# Altium schematic sheet Aux_SC.SchDoc(Aux_SC)
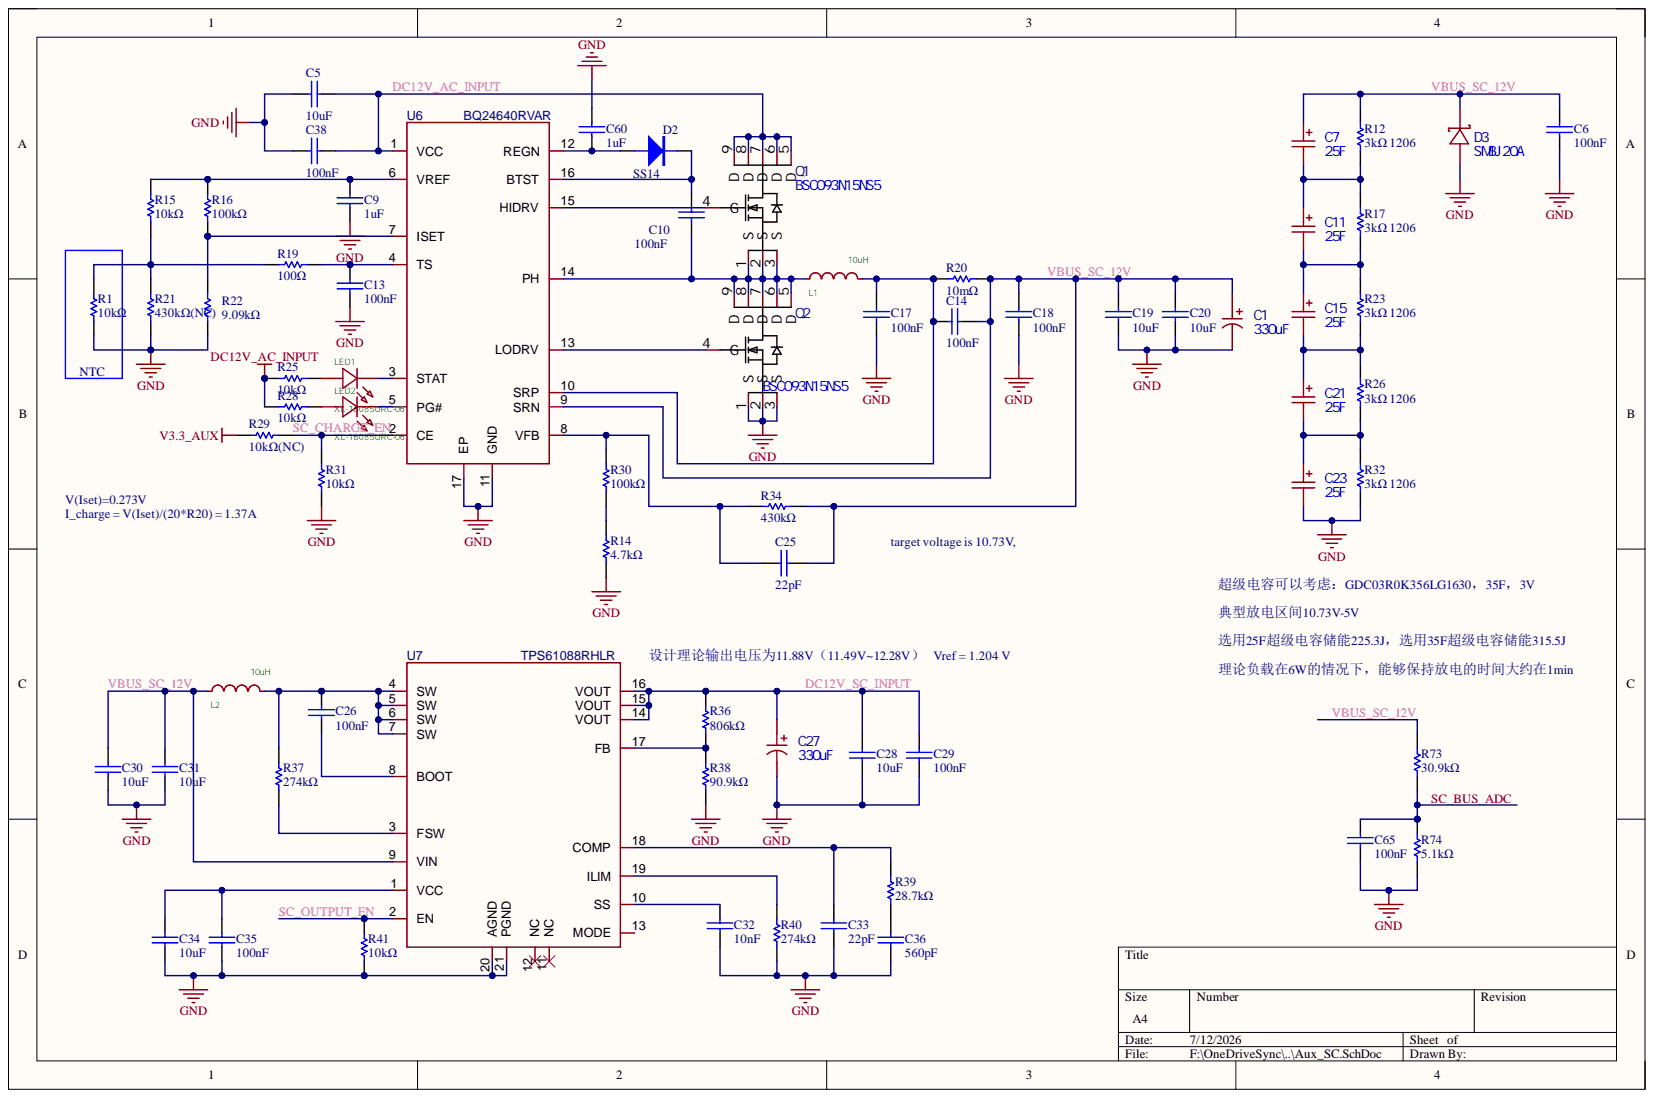
[diagram p0: Aux_SC.SchDoc]

## Parts
C1  330uF  [CAP-SMD_BD8.0-L8.3-W8.3-FD]
C5  10uF  [0805C]
C6  100nF  [0805C]
C7  25F  [CAP-TH_BD16.0-P7.50-D1.2-FD]
C9  1uF  [0402C]
C10  100nF  [0603C]
C11  25F  [CAP-TH_BD16.0-P7.50-D1.2-FD]
C13  100nF  [0603C]
C14  100nF  [0402C]
C15  25F  [CAP-TH_BD16.0-P7.50-D1.2-FD]
C17  100nF  [0603C]
C18  100nF  [0603C]
C19  10uF  [0805C]
C20  10uF  [0805C]
C21  25F  [CAP-TH_BD16.0-P7.50-D1.2-FD]
C23  25F  [CAP-TH_BD16.0-P7.50-D1.2-FD]
C25  22pF  [0402C]
C26  100nF  [0603C]
C27  330uF  [CAP-SMD_BD8.0-L8.3-W8.3-FD]
C28  10uF  [0805C]
C29  100nF  [0603C]
C30  10uF  [0805C]
C31  10uF  [0805C]
C32  10nF  [0402C]
C33  22pF  [0402C]
C34  10uF  [0805C]
C35  100nF  [0603C]
C36  560pF  [0402C]
C38  100nF  [0603C]
C60  1uF  [0402C]
C65  100nF  [0402C]
D2  SS14  [SOD-123]
D3  SMBJ20A  [SMB_L4.6-W3.6-LS5.3-RD]
L1  10uH  [IND-SMD_L5.7-W5.2_SMPI0520]
L2  10uH  [IND-SMD_L5.7-W5.2_SMPI0520]
LED1  XL-1608SURC-06  [LED0603-RD_RED]
LED2  XL-1608SURC-06  [LED0603-RD_RED]
Q1  BSC093N15NS5  [PG-TDSON-8_L5.0-W6.0-P1.27-LS6.2-BL-EP]
Q2  BSC093N15NS5  [PG-TDSON-8_L5.0-W6.0-P1.27-LS6.2-BL-EP]
R1  10kΩ  [0402R]
R12  3kΩ 1206  [1206R]
R14  4.7kΩ  [0402R]
R15  10kΩ  [0402R]
R16  100kΩ  [0402R]
R17  3kΩ 1206  [1206R]
R19  100Ω  [0402R]
R20  10mΩ  [1206R]
R21  430kΩ(NC)  [0402R]
R22  9.09kΩ  [0402R]
R23  3kΩ 1206  [1206R]
R25  10kΩ  [0402R]
R26  3kΩ 1206  [1206R]
R28  10kΩ  [0402R]
R29  10kΩ(NC)  [0402R]
R30  100kΩ  [0402R]
R31  10kΩ  [0402R]
R32  3kΩ 1206  [1206R]
R34  430kΩ  [0402R]
R36  806kΩ  [0402R]
R37  274kΩ  [0402R]
R38  90.9kΩ  [0402R]
R39  28.7kΩ  [0402R]
R40  274kΩ  [0402R]
R41  10kΩ  [0402R]
R73  30.9kΩ  [0402R]
R74  5.1kΩ  [0402R]
U6  BQ24640RVAR  [VQFN-16_L3.6-W3.6-P0.50-BL-EP]
U7  TPS61088RHLR  [VQFN-20_L4.6-W3.6-P0.50-BL]

## Nets
GND: C1.2 C5.2 C6.1 C9.1 C13.1 C17.1 C18.1 C19.1 C20.1 C23.2 C27.2 C28.1 C29.1 C30.1 C31.1 C32.1 C33.1 C34.1 C35.1 C36.1 C38.2 C60.2 C65.1 D3.2 Q2.1(S) Q2.2(S) Q2.3(S) R1.1 R14.2 R21.1 R22.1 R31.2 R32.1 R38.1 R40.1 R41.1 R74.1 U6.11(GND) U6.17(EP) U7.20(AGND) U7.21(PGND)
V3.3_AUX: R29.2
DC12V_AC_INPUT: C5.1 C38.1 Q1.5(D) Q1.6(D) Q1.7(D) Q1.8(D) Q1.9(D) R25.2 R28.2 U6.1(VCC)
DC12V_SC_INPUT: C27.1 C28.2 C29.2 R36.2 U7.14(VOUT) U7.15(VOUT) U7.16(VOUT)
SC_BUS_ADC: C65.2 R73.1 R74.2
SC_CHARGE_EN: R29.1 R31.1 U6.2(CE)
SC_OUTPUT_EN: R41.2 U7.2(EN)
VBUS_SC_12V: C1.1 C6.2 C7.1 C14.2 C18.2 C19.2 C20.2 C25.1 C30.2 C31.2 D3.1 L2.1 R12.2 R20.2 R34.1 R73.2 U6.9(SRN) U7.9(VIN)
NetC7_2: C7.2 C11.1 R12.1 R17.2
NetC9_2: C9.2 R15.2 R16.2 U6.6(VREF)
NetC10_1: C10.1 L1.1 Q1.1(S) Q1.2(S) Q1.3(S) Q2.5(D) Q2.6(D) Q2.7(D) Q2.8(D) Q2.9(D) U6.14(PH)
NetC10_2: C10.2 D2.K U6.16(BTST)
NetC11_2: C11.2 C15.1 R17.1 R23.2
NetC13_2: C13.2 R19.1 U6.4(TS)
NetC14_1: C14.1 C17.2 L1.2 R20.1 U6.10(SRP)
NetC15_2: C15.2 C21.1 R23.1 R26.2
NetC21_2: C21.2 C23.1 R26.1 R32.2
NetC25_2: C25.2 R30.1 R34.2 U6.8(VFB)
NetC26_1: C26.1 U7.8(BOOT)
NetC26_2: C26.2 L2.2 R37.2 U7.4(SW) U7.5(SW) U7.6(SW) U7.7(SW)
NetC32_2: C32.2 U7.10(SS)
NetC33_2: C33.2 R39.2 U7.18(COMP)
NetC34_2: C34.2 C35.2 U7.1(VCC)
NetC36_2: C36.2 R39.1
NetC60_1: C60.1 D2.A U6.12(REGN)
NetLED1_1: LED1.1 U6.3(STAT)
NetLED1_2: LED1.2 R25.1
NetLED2_1: LED2.1 U6.5(PG#)
NetLED2_2: LED2.2 R28.1
NetQ1_4: Q1.4(G) U6.15(HIDRV)
NetQ2_4: Q2.4(G) U6.13(LODRV)
NetR1_2: R1.2 R15.1 R19.2 R21.2
NetR14_1: R14.1 R30.2
NetR16_1: R16.1 R22.2 U6.7(ISET)
NetR36_1: R36.1 R38.2 U7.17(FB)
NetR37_1: R37.1 U7.3(FSW)
NetR40_2: R40.2 U7.19(ILIM)

Not connected: U7 11(NC) 12(NC) 13(MODE)

## Library data of the parts
C1 C27 = RVT1E331M0810 330UF 25V: C970701; Manufacturer: DMBJ(振宝佳); Add into BOM: yes; Lifetime: 2800hrs@105℃; Ripple Current: 228mA@120Hz; Tolerance: ±20%; Voltage Rating: 25V; Component Kind: Standard; Convert to PCB: yes; Datasheet: https://item.szlcsc.com/datasheet/RVT1E331M0810%2520330UF%252025V/1060429.html; Device: RVT1E331M0810 330UF 25V; Diameter: 8mm; Equivalent Series Resistance(ESR): -; Height - Seated (Max): 10.2mm; JLCPCB Part Class: 扩展库; LCSC Part Name: 330uF ±20% 25V; Manufacturer Part: RVT1E331M0810 330UF 25V; Operating Temperature: -55℃~+105℃; PCB3D: CAP-SMD_BD8.0-L8.3-W8.3-FD; Supplier: LCSC; Supplier Footprint: SMD,D8xL10.2mm; Supplier Part: C970701; Symbol: RVT1E331M0810 330UF 25V; Value: 330uF
C5 C6 C9 C10 C13 C14 C17-C20 C25 C26 C28-C36 C38 C60 C65 = CAP: 无极性电容; Component Kind: Standard; Library Name: 电容.IntLib
C7 C11 C15 C21 C23 = ERF1VM222L25OT: BCT2R7M256YS1625A2NP01; Manufacturer: EDLCON(绿宝石); Manufacturer Part: BCC2R7S205YS0812A2NS01; Add into BOM: yes; Lifetime: 6000hrs@105℃; Pin Spacing: 7.5mm; Symbol: CAP; Tolerance: ±20%; Voltage Rating: 35V; Component Kind: Standard; Convert to PCB: yes; Datasheet: https://item.szlcsc.com/datasheet/ERF1VM222L25OT/107763.html; Device: ERF1VM222L25OT; Diameter: 16mm; Equivalent Series Resistance(ESR): -; Height - Seated (Max): 25mm; JLCPCB Part Class: Extended Part; LCSC Part Name: 2200uF ±20% 35V; PCB3D: CAP-TH_D16.0-H25.0-P7.50; Ripple Current: -; Supplier: LCSC; Supplier Footprint: 插件,D16xL25mm; Supplier Part: C39832982; Value: 25F
D2 = DIODE: 普通二极管; Component Kind: Standard; Library Name: 二极管.IntLib
D3 = SMBJ20A_C151257: Manufacturer: Littelfuse(美国力特); Add into BOM: yes; Clamping Voltage: 32.4V; Peak Pulse Current (Ipp): 18.6A; Peak Pulse Power Dissipation (Ppp): 600W; Reverse Stand-Off Voltage (Vrwm): 20V; Type: TVS; Voltage - Breakdown: 22.2V; Component Kind: Standard; Convert to PCB: yes; Datasheet: https://item.szlcsc.com/datasheet/SMBJ20A/162597.html; Device: SMBJ20A_C151257; JLCPCB Part Class: 扩展库; LCSC Part Name: SMBJ20A; Manufacturer Part: SMBJ20A; PCB3D: SMB_L4.6-W3.6-LS5.3-RD; Supplier: LCSC; Supplier Footprint: SMB(DO-214AA); Supplier Part: C151257; Symbol: SMBJ20A_C151257
L1 L2 = FXL0630-3R3-M: L; Manufacturer: cjiang(长江微电); Add into BOM: yes; DC Resistance (DCR): 22mΩ; Rated Current: 8.5A; Saturation Current (Isat): 9.5A; Tolerance: ±20%; Type: 一体成型电感; Value: 3.3uH; Component Kind: Standard; Convert to PCB: yes; Datasheet: https://atta.szlcsc.com/upload/public/pdf/source/20240426/3189EA8206330E2108542F9E34E540E7.pdf; Device: FXL0630-3R3-M; JLCPCB Part Class: Extended Part; LCSC Part Name: 3.3uH ±20% 9.5A; Manufacturer Part: FXL0630-3R3-M; PCB3D: IND-SMD_L7.0-W6.6-H3.0; Supplier: LCSC; Supplier Footprint: SMD,6.6x7mm; Supplier Part: C167219; Symbol: FXL0630-3R3-M; Library Name: GMP_PB_Core_Lib.SchLib
LED1 LED2 = XL-1608SURC-06: LED 0603; Manufacturer: XINGLIGHT(成兴光); Add into BOM: yes; Forward Current: 20mA; Illumination Color: 红色; Lens Color: 无色透明透镜; Luminous Intensity: 225mcd; Peak Wavelength: 625nm; Power(Watts): 50mW; Viewing Angle: 120°; Voltage - Forward(Vf): 2.3V; Wavelength - Dominant: 620nm~630nm; Color Temperature: -; Component Kind: Standard; Convert to PCB: yes; Datasheet: https://item.szlcsc.com/datasheet/XL-1608SURC-06/1051408.html; Device: XL-1608SURC-06; Diode Configuration: -; JLCPCB Part Class: Extended Part; Lamp Holder Type: -; LCSC Part Name: 0603红色贴片式发光二极管; Manufacturer Part: XL-1608SURC-06; Mounting Style: 正贴; Operating Temperature: -40℃~+85℃; PCB3D: LED0603-RD_RED; Supplier: LCSC; Supplier Footprint: 0603; Supplier Part: C965799; Symbol: XL-1608SURC-06; Library Name: GMP_PB_Core_Lib.SchLib
Q1 Q2 = BSC093N15NS5: MOSFET Infineon 150V, 87A, 9.3mΩ@10V, 44A; Manufacturer: Infineon(英飞凌); Add into BOM: yes; Gate Charge(Qg): 40.7nC@10V; Gate Threshold Voltage (Vgs(th)): 4.6V; Input Capacitance(Ciss): 3.23nF@75V; Number: 1个N沟道; Pd - Power Dissipation: 139W; Reverse Transfer Capacitance (Crss@Vds): 26pF@75V; Component Kind: Standard; Convert to PCB: yes; Current - Continuous Drain(Id): 87A; Datasheet: https://item.szlcsc.com/datasheet/BSC093N15NS5/456361.html; Device: BSC093N15NS5; Drain to Source Voltage: 150V; JLCPCB Part Class: 扩展库; LCSC Part Name: 1个N沟道 耐压:150V 电流:87A; Manufacturer Part: BSC093N15NS5; Operating Temperature: -55℃~+150℃; PCB3D: PG-TDSON-8_L5.0-W6.0-H1.0-P1.27; RDS(on): 9.3mΩ@10V,44A; Supplier: LCSC; Supplier Footprint: TDSON-8(5x6); Supplier Part: C454270; Symbol: BSC093N15NS5; Library Name: GMP_PB_Core_Lib.SchLib
R1 R12 R14-R17 R19-R23 R25 R26 R28-R32 R34 R36-R41 R73 R74 = RES: Component Kind: Standard; Library Name: 电阻.IntLib
U6 = BQ24640RVAR: PWR SC Charger; Manufacturer: TI(德州仪器); AddInBom: yes; ModelId: 8916cc6287ee41c0acc8efbfa0c8a038; ModelName: VQFN-16_L3.5-W3.5-H1.0-P0.50; ModelTransform: 138.5824,138.5824,0,90,0,0,0,0,0; Component Kind: Standard; ConvertToPcb: yes; Datasheet: https://www.ti.com/cn/lit/gpn/bq24640; Device: BQ24640RVAR; FootprintName: VQFN-16_L3.6-W3.6-P0.50-BL-EP; JLCPCB Part Class: Extended Part; LCSC Part Name: 高效同步开关模式超级电容器充电器; ManufacturerPart: BQ24640RVAR; Operating Temperature: -40℃~+85℃; Supplier: LCSC; Supplier Footprint: VQFN-16-EP(3.6x3.6); SupplierPart: C545157; Symbol: BQ24640RVAR; Library Name: GMP_PB_Core_Lib.SchLib
U7 = TPS61088RHLR: PWR Boost, 2.7V~12V -> 4.5V~12.6V, Im=10A; Manufacturer: TI(德州仪器); AddInBom: yes; Function: 升压型; ModelId: 5fea786b771f49529c9badc1d7bb6c83; ModelName: VQFN-20_L4.5-W3.5-H1.0-P0.50; ModelTransform: 177.952,138.5824,0,0,0,0,0.078,0,0; Number of Outputs: 1; Output Current: 10A; Output Type: 可调; Switch tube (built-in/external): 内置; Switching Frequency: 200kHz~2.2MHz; Synchronous Rectification: 是; Topology: 升压式; Component Kind: Standard; ConvertToPcb: yes; Datasheet: https://www.ti.com/cn/lit/gpn/tps61088; Device: TPS61088RHLR; FootprintName: VQFN-20_L4.6-W3.6-P0.50-BL; Input Voltage: 2.7V~12V; JLCPCB Part Class: Extended Part; LCSC Part Name: 全集成同步升压转换器; ManufacturerPart: TPS61088RHLR; Operating Temperature: -40℃~+85℃; Output Voltage: 4.5V~12.6V; Quiescent Current (Iq): -; Supplier: LCSC; Supplier Footprint: VQFN-20-EP(3.6x4.6); SupplierPart: C87357; Symbol: TPS61088RHLR; Library Name: GMP_PB_Core_Lib.SchLib
